# Altium schematic sheet NC_Circuit.SchDoc(NC_Circuit)
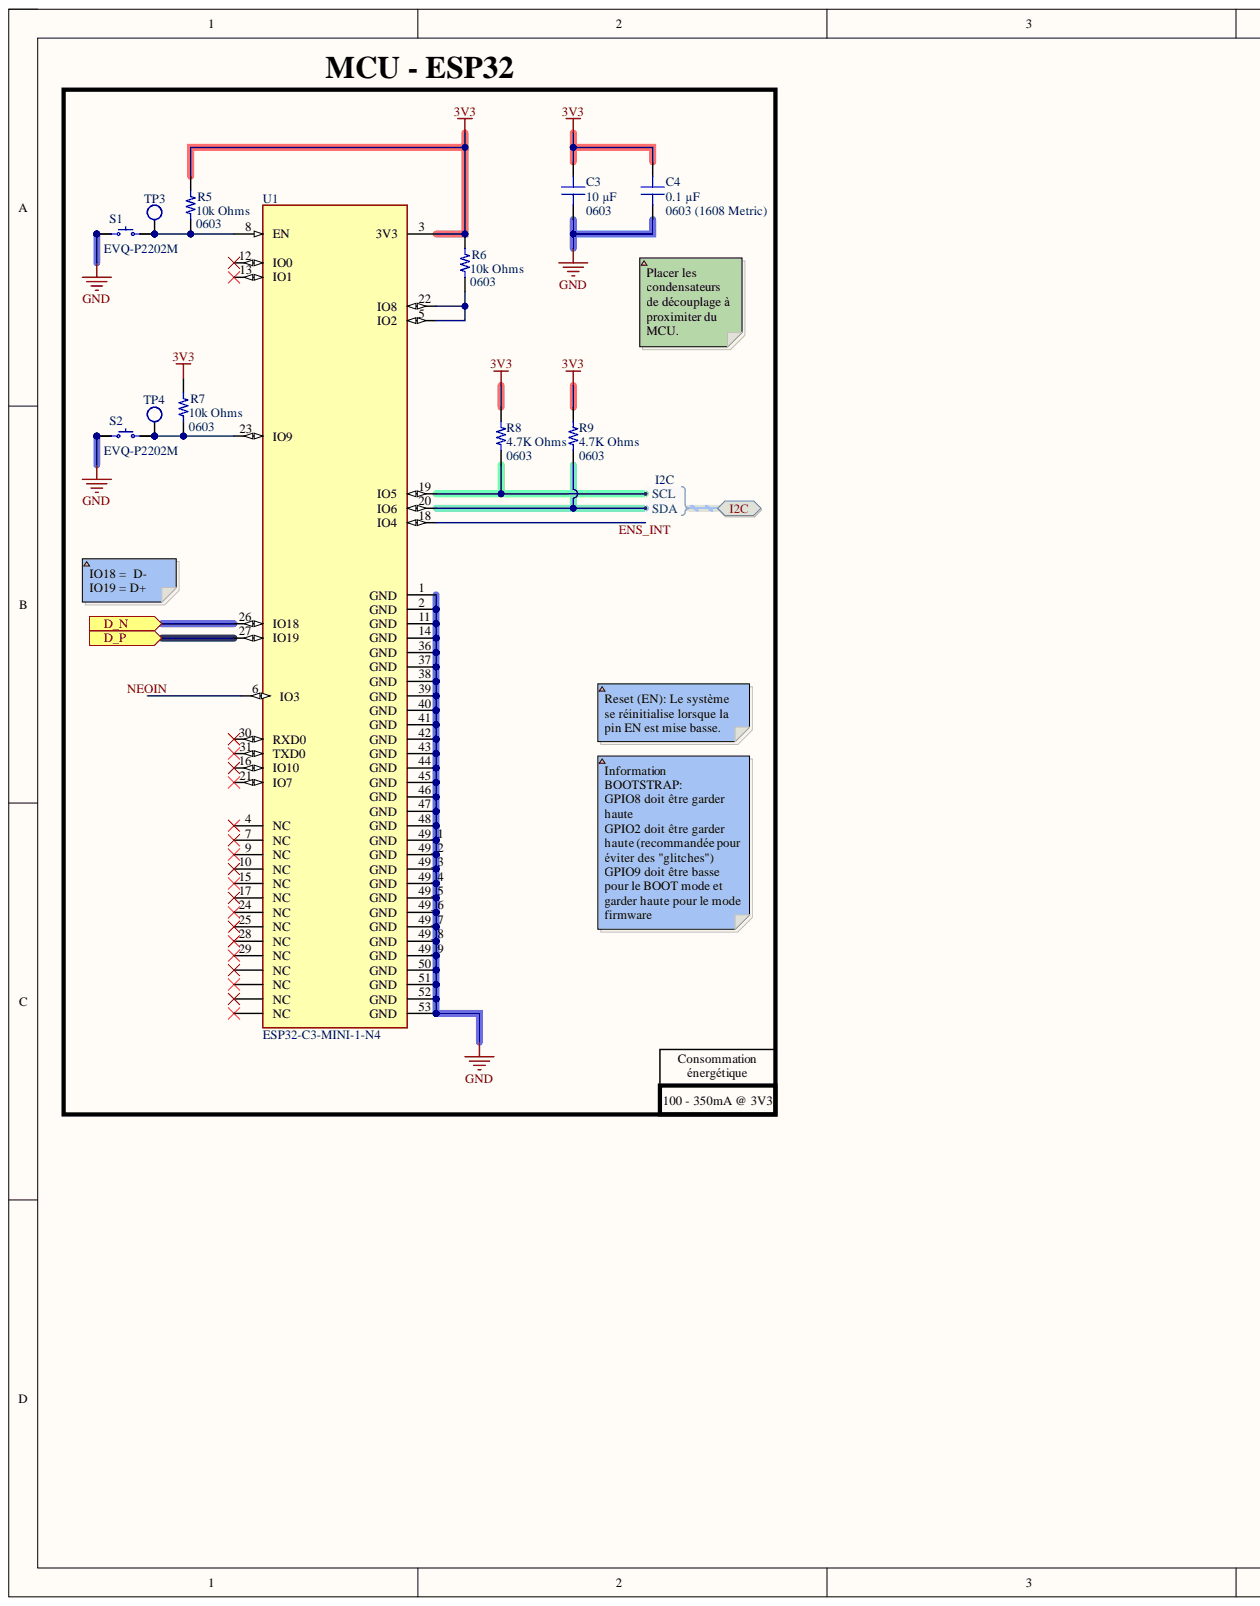
[diagram p0: NC_Circuit.SchDoc, left]
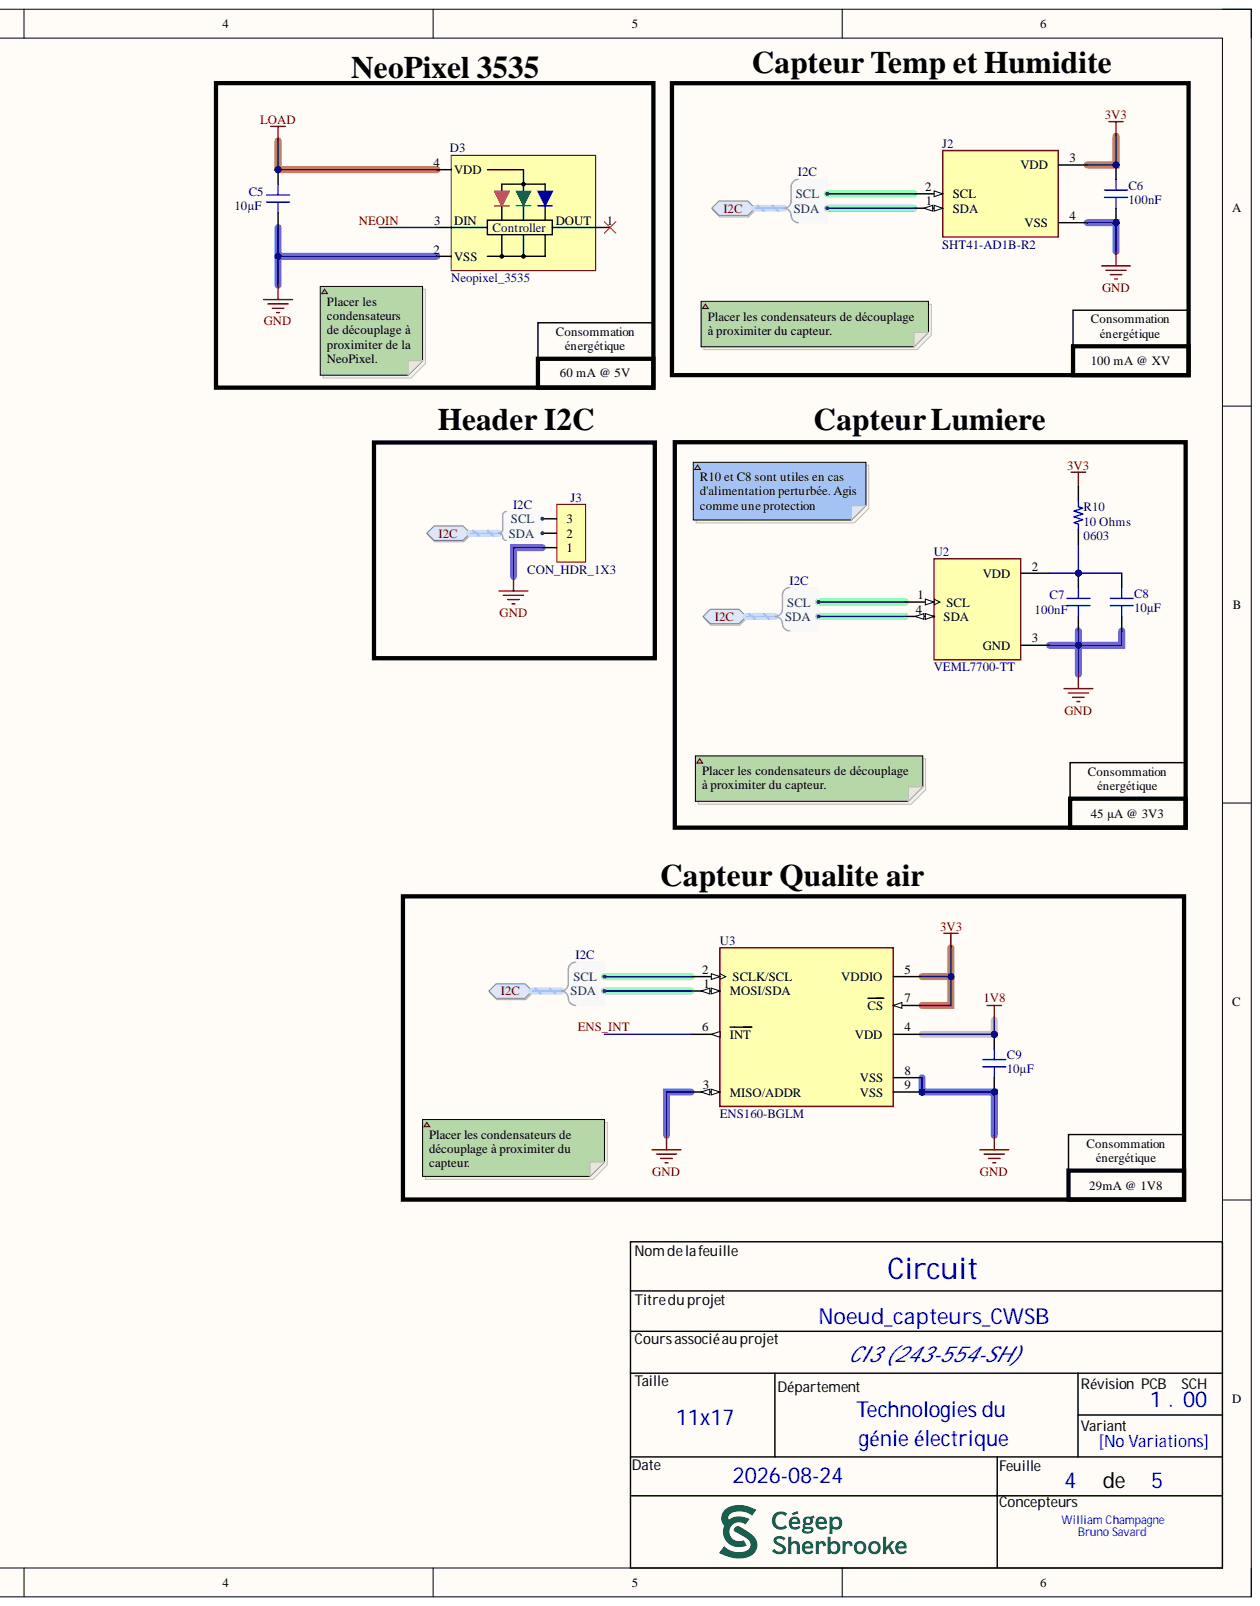
[diagram p1: NC_Circuit.SchDoc, right]

## Parts
C3  10 µF  Device Package: 0603  [CAP 0603_1608]
C4  0.1 µF  [CAP 0603_1608]
C5  Capacitance: 10µF  [CAP 0603_1608]  (hidden comment: 1µF)
C6  Capacitance: 100nF  [CAP 0603_1608]  (hidden comment: 1µF)
C7  Capacitance: 100nF  [CAP 0603_1608]  (hidden comment: 1µF)
C8  Capacitance: 10µF  [CAP 0603_1608]  (hidden comment: 1µF)
C9  Capacitance: 10µF  [CAP 0603_1608]  (hidden comment: 1µF)
D3  Neopixel_3535  [Neopixel_3535-Footprint-1]
J2  SHT41-AD1B-R2  [SHT4X]
J3  CON_HDR_1X3  [CON_HDR_1x3]
R5  10k Ohms  Supplier Device Package: 0603  [RES 0603_1608]
R6  10k Ohms  Supplier Device Package: 0603  [RES 0603_1608]
R7  10k Ohms  Supplier Device Package: 0603  [RES 0603_1608]
R8  4.7K Ohms  Supplier Device Package: 0603  [RES 0603_1608]
R9  4.7K Ohms  Supplier Device Package: 0603  [RES 0603_1608]
R10  10 Ohms  Supplier Device Package: 0603  [RES 0603_1608]
S1  EVQ-P2202M  [PANASONIC EVQP2]
S2  EVQ-P2202M  [PANASONIC EVQP2]
TP3  TPO_SMD_ROUND_2MM  [TPO_SMD_ROUND_2MM]  (value not drawn)  (no BOM)
TP4  TPO_SMD_ROUND_2MM  [TPO_SMD_ROUND_2MM]  (value not drawn)  (no BOM)
U1  ESP32-C3-MINI-1-N4  [XCVR_ESP32-C3-MINI-1-N4]
U2  VEML7700-TT  [XDCR_VEML7700-TT]
U3  ENS160-BGLM  [XDCR_ENS160-BGLM]

## Ports
D_N, D_P, I2C, I2C.SCL, I2C.SDA

## Nets
1V8: C9.2 U3.4(VDD)
3V3: C3.2 C4.2 C6.2 J2.3(VDD) R5.1 R6.1 R7.1 R8.2 R9.2 R10.2 U1.3(3V3) U3.5(VDDIO) U3.7(CS)
GND: C3.1 C4.1 C5.1 C6.1 C7.1 C8.1 C9.1 D3.2(VSS) J2.4(VSS) J3.1 S1.1 S1.2 S2.1 S2.2 U1.1(GND) U1.2(GND) U1.11(GND) U1.14(GND) U1.36(GND) U1.37(GND) U1.38(GND) U1.39(GND) U1.40(GND) U1.41(GND) U1.42(GND) U1.43(GND) U1.44(GND) U1.45(GND) U1.46(GND) U1.47(GND) U1.48(GND) U1.49_1(GND) U1.49_2(GND) U1.49_3(GND) U1.49_4(GND) U1.49_5(GND) U1.49_6(GND) U1.49_7(GND) U1.49_8(GND) U1.49_9(GND) U1.50(GND) U1.51(GND) U1.52(GND) U1.53(GND) U2.3(GND) U3.3(MISO/ADDR) U3.8(VSS) U3.9(VSS)
LOAD: C5.2 D3.4(VDD)
D_N: U1.26(IO18) ; port D_N
D_P: U1.27(IO19) ; port D_P
ENS_INT: U1.18(IO4) U3.6(INT)
I2C.SCL: J2.2(SCL) J3.3 R8.1 U1.19(IO5) U2.1(SCL) U3.2(SCLK/SCL) ; port I2C
I2C.SDA: J2.1(SDA) J3.2 R9.1 U1.20(IO6) U2.4(SDA) U3.1(MOSI/SDA) ; port I2C
NEOIN: D3.3 U1.6(IO3)
NetC7_2: C7.2 C8.2 R10.1 U2.2(VDD)
NetR5_2: R5.2 S1.3 S1.4 TP3.1 U1.8(EN)
NetR6_2: R6.2 U1.5(IO2) U1.22(IO8)
NetR7_2: R7.2 S2.3 S2.4 TP4.1 U1.23(IO9)

Not connected: D3 1; U1 4(NC) 7(NC) 9(NC) 10(NC) 12(IO0) 13(IO1) 15(NC) 16(IO10) 17(NC) 21(IO7) 24(NC) 25(NC) 28(NC) 29(NC) 30(RXD0) 31(TXD0) 32(NC) 33(NC) 34(NC) 35(NC)

## Library data of the parts
C3 = GRM188R60J106ME47D: CAP CER 10UF 6.3V X5R 0603; manufacturer: Murata Electronics; Database Table Name: Capacitors - Ceramic - 0603; Tolerance: ±20%; Applications: General Purpose; Capacitance: 10 µF; Component Kind: Standard; componentlink1description: Datasheet; componentlink1url: https://www.murata.com/~/media/webrenewal/support/library/catalog/products/capacitor/mlcc/c02e.ashx?la=en-us; componentlink2description: DigiKey Link; componentlink2url: https://www.digikey.com/en/products/detail/murata-electronics/GRM188R60J106ME47D/965910; lastupdated: 06/04/2026 19:23:52; Library Name: Celestial Altium Library - altium_library.DbLib; Lifecycle Status: Active; Minimum Order: 1; Mounting Type: Surface Mount, MLCC; Operating Temperature: -55°C ~ 85°C; Package / Case: 0603 (1608 Metric); packaging: TapeAndReel; Part Number: GRM188R60J106ME47D; Part Status: Active; partid: 2351; price: 0.01; series: GRM; Size / Dimension: 0.063" L x 0.031" W (1.60mm x 0.80mm); Supplier 1: DigiKey; Supplier Part Number 1: 490-3896-1-ND; Temperature Coefficient: X5R; Thickness (Max): 0.035" (0.90mm); value: 10 µF; Voltage - Rated: 6.3V
C4 = 6R3X14W104MV4T: CAP CER 0.1UF 6.3V X7R 0603; manufacturer: Johanson Dielectrics Inc.; Database Table Name: Capacitors - Ceramic - 0603; Tolerance: ±20%; Applications: Bypass, Decoupling; Capacitance: 0.1 µF; Component Kind: Standard; componentlink1description: Datasheet; componentlink1url: https://www.johansondielectrics.com/downloads/x2y-filter-and-decoupling-capacitors.pdf; componentlink2description: DigiKey Link; componentlink2url: https://www.digikey.com/en/products/detail/johanson-dielectrics-inc/6R3X14W104MV4T/1556331; Device Package: 0603 (1608 Metric); Features: Low ESL (X2Y); lastupdated: 06/04/2026 19:23:51; Library Name: Celestial Altium Library - altium_library.DbLib; Lifecycle Status: Active; Minimum Order: 1; Mounting Type: Surface Mount, MLCC; Operating Temperature: -55°C ~ 125°C; Package / Case: 0603 (1608 Metric); packaging: TapeAndReel; Part Number: 6R3X14W104MV4T; Part Status: Active; partid: 2650; price: 0.08; series: X2Y®; Size / Dimension: 0.064" L x 0.035" W (1.63mm x 0.89mm); Supplier 1: DigiKey; Supplier Part Number 1: 709-1009-1-ND; Temperature Coefficient: X7R; Thickness (Max): 0.026" (0.66mm); value: 0.1 µF; Voltage - Rated: 6.3V
C5-C9 = 06035C105KAT2A: CAP CER 1UF 50V X7R 0603; manufacturer: AVX Corporation; Database Table Name: Capacitors - Ceramic - 0603; Tolerance: ±10%; Applications: General Purpose; Component Kind: Standard; componentlink1description: Datasheet; componentlink1url: http://datasheets.avx.com/X7RDielectric.pdf; componentlink2description: DigiKey Link; componentlink2url: http://digikey.com/product-detail/en/avx-corporation/06035C105KAT2A/478-10073-1-ND/6564295; Device Package: 0603 (1608 Metric); lastupdated: 2020-09-02 1:03:35 AM; Library Name: Celestial Altium Library - altium_library.DbLib; Lifecycle Status: Active; Minimum Order: 1; Mounting Type: Surface Mount, MLCC; Operating Temperature: -55°C ~ 125°C; Package / Case: 0603 (1608 Metric); packaging: TapeAndReel; Part Number: 06035C105KAT2A; Part Status: Active; partid: 2905; price: 0.15; series: -; Size / Dimension: 0.063" L x 0.032" W (1.60mm x 0.81mm); Supplier 1: DigiKey; Supplier Part Number 1: 478-10073-1-ND; Temperature Coefficient: X7R; Thickness (Max): 0.020" (0.50mm); value: 1µF; Voltage - Rated: 50V
D3 = CMP-851-000001-3: Neopixel Mini 3535 Rgb Leds W/ Integrated Driver Chip - White - Pack Of 10; Component Kind: Standard; Library Name: Cegep de Sherbrooke
J2 = SHT41-AD1B-R2: Digital Relative Humidity Temperature Sensor, Â±1.8 / max 2.5 %RH, Â±0.2 Â°C, Ultra-Low-Power, with filtermembrane; MF: Sensirion; Availability: In Stock; Check_prices: https://www.snapeda.com/parts/SHT41-AD1B-R2/Sensirion/view-part/?ref=eda; Component Kind: Standard; Library Name: SHT41-AD1B-R2.IntLib; MP: SHT41-AD1B-R2; Package: Package; Price: None; Purchase-URL: https://pricing.snapeda.com/search/part/SHT41-AD1B-R2/?ref=eda; SnapEDA_Link: https://www.snapeda.com/parts/SHT41-AD1B-R2/Sensirion/view-part/?ref=snap
J3 = CMP-005-000001-1: CON - Header 1x3 pins-100Mils - TH; Polarized: No; Assembly Type: TH; Component Kind: Standard; Component Type: Standard; Design Item ID: CON_HDR_1X3; Package: TH; Pin Count: 3; Library Name: Cegep de Sherbrooke; Source: CEGEP_SHERBROOKE_TGE_LIBRARY.SchLib
R5-R7 = ERJ-UP3J151V: RES 150 OHM 5% 1/4W 0603; Manufacturer: Panasonic Electronic Components; Database Table Name: Resistors - Surface Mount - 0603; Power (Watts): 0.25W, 1/4W; Resistance: 150 Ohms; Temperature Coefficient: ±200ppm/°C; Component Kind: Standard; ComponentLink1Description: Datasheet; ComponentLink1URL: https://b2b-api.panasonic.eu/file_stream/pids/fileversion/4484; ComponentLink2Description: DigiKey Link; ComponentLink2URL: https://www.digikey.com/en/products/detail/panasonic-electronic-components/ERJ-UP3J151V/12092481; Composition: Thick Film; Device Package: 0603 (1608 Metric); Features: Anti-Sulfur, Automotive AEC-Q200, Pulse Withstanding; Height - Seated (Max): 0.022" (0.55mm); LastUpdated: 2024-02-09 8:41:36 PM; Library Name: Celestial Altium Library - altium_library.DbLib; Lifecycle Status: Active; Minimum Order: 1; Number of Terminations: 2; Operating Temperature: -55°C ~ 155°C; Package / Case: 0603 (1608 Metric); Packaging: TapeAndReel; Part Number: ERJ-UP3J151V; Part Status: Active; PartId: 63618; Price: 0.02; Ratings: AEC-Q200; Series: ERJ-UP3; Size / Dimension: 0.063" L x 0.031" W (1.60mm x 0.80mm); Supplier 1: DigiKey; Supplier Part Number 1: 10-ERJ-UP3J151VCT-ND; Tolerance: ±5%; Value: 10k Ohms
R8-R10 = ERJ-UP3J151V: RES 150 OHM 5% 1/4W 0603; Manufacturer: Panasonic Electronic Components; Database Table Name: Resistors - Surface Mount - 0603; Power (Watts): 0.25W, 1/4W; Resistance: 5.1k Ohms; Temperature Coefficient: ±200ppm/°C; Component Kind: Standard; ComponentLink1Description: Datasheet; ComponentLink1URL: https://b2b-api.panasonic.eu/file_stream/pids/fileversion/4484; ComponentLink2Description: DigiKey Link; ComponentLink2URL: https://www.digikey.com/en/products/detail/panasonic-electronic-components/ERJ-UP3J151V/12092481; Composition: Thick Film; Device Package: 0603 (1608 Metric); Features: Anti-Sulfur, Automotive AEC-Q200, Pulse Withstanding; Height - Seated (Max): 0.022" (0.55mm); LastUpdated: 2024-02-09 8:41:36 PM; Library Name: Celestial Altium Library - altium_library.DbLib; Lifecycle Status: Active; Minimum Order: 1; Number of Terminations: 2; Operating Temperature: -55°C ~ 155°C; Package / Case: 0603 (1608 Metric); Packaging: TapeAndReel; Part Number: ERJ-UP3J151V; Part Status: Active; PartId: 63618; Price: 0.02; Ratings: AEC-Q200; Series: ERJ-UP3; Size / Dimension: 0.063" L x 0.031" W (1.60mm x 0.80mm); Supplier 1: DigiKey; Supplier Part Number 1: 10-ERJ-UP3J151VCT-ND; Tolerance: ±5%
  R8 R9: Value: 4.7K Ohms
  R10: Value: 10 Ohms
S1 S2 = EVQ-P2202M: SWITCH TACTILE SPST-NO 0.02A 15V; Database Table Name: Switches - Tactile; Component Kind: Standard; Library Name: Celestial Altium Library - altium_library.DbLib; Part Number: EVQ-P2202M
TP3 TP4 = CMP-007-000003-1: TPO - TEST POINT ROUND SMD PAD ONLY  - 2 MM; Assembly Type: NONE; Component Type: Standard (No BOM); Design Item ID: TPO_SMD_ROUND_2MM; Package: 2 MM ROUND; Pin Count: 1; Library Name: Cegep de Sherbrooke; Source: CEGEP_SHERBROOKE_TGE_LIBRARY.SchLib
U1 = ESP32-C3-MINI-1-N4: MF: {'manufacturer': u'Espressif Systems'}; Availability: Unavailable; Component Kind: Standard; Library Name: ESP32-C3-MINI-1-N4.IntLib; MP: ESP32-C3-MINI-1-N4; Package: None; Price: None
U2 = VEML7700-TT: Optical Sensor Ambient - IÂ²C 4-SMD, J-Lead; MF: Vishay Semiconductor; Check_prices: https://www.snapeda.com/parts/VEML7700-TT/Vishay/view-part/?ref=eda; Component Kind: Standard; Library Name: VEML7700-TT.IntLib; MP: VEML7700-TT; Package: SMD-4 Vishay Semiconductor; SnapEDA_Link: https://www.snapeda.com/parts/VEML7700-TT/Vishay/view-part/?ref=snap
U3 = ENS160-BGLM: Air Quality, Carbon Dioxide (CO2), Metal Oxide (MOX) Sensor IÂ²C, SPI; MF: ScioSense; Check_prices: https://www.snapeda.com/parts/ENS160-BGLM/ScioSense/view-part/?ref=eda; Component Kind: Standard; Library Name: ENS160-BGLM.IntLib; MP: ENS160-BGLM; Package: LGA-9 ScioSense; SnapEDA_Link: https://www.snapeda.com/parts/ENS160-BGLM/ScioSense/view-part/?ref=snap
